# Altium schematic sheet Sheet1.SchDoc(Sheet1)
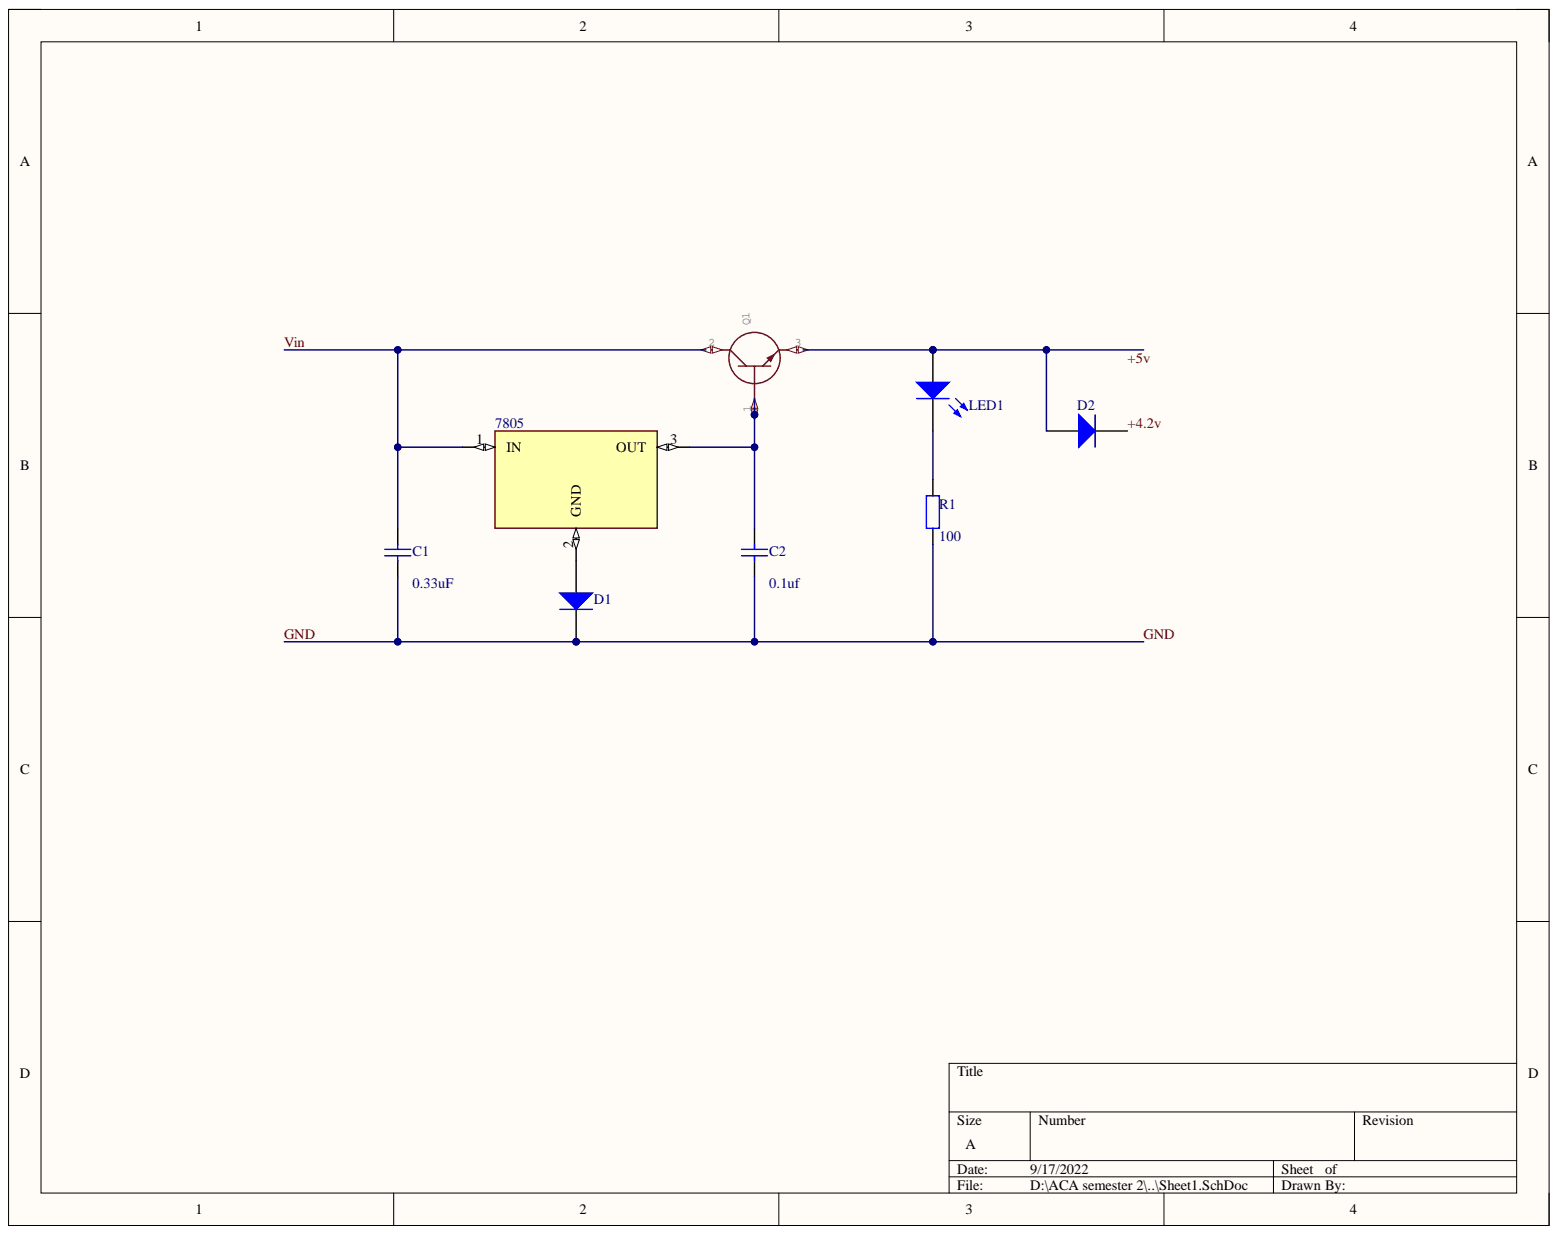
[diagram p0: Sheet1.SchDoc]

## Parts
7805  LM7805  [TO220]  (value not drawn)
C1  value 0.33uF  [RAD-0.3]  (hidden comment: Cap)
C2  value 0.1uf  [RAD-0.3]  (hidden comment: Cap)
D1  Diode 1N4007  [DO-41]  (value not drawn)
D2  Diode 1N4007  [DO-41]  (value not drawn)
Q1  (no value)  [TO304P498X1010X2089-3P]
R1  value 100  [AXIAL-0.4]  (hidden comment: Res2)
  LED1  [LED-1]

## Nets
Net_1: D2.1 Q1.3 .1
Net_2: R1.2 .2
+4.2v: D2.2
GND: C1.1 C2.1 D1.2 R1.1
Vin: 7805.1 C1.2 Q1.2
Net7805_2: 7805.2 D1.1
Net7805_3: 7805.3 C2.2 Q1.1
+5v:

## Library data of the parts
C1 C2 = Cap: Capacitor; Component Kind: Standard; LatestRevisionDate: 17-Jul-2002; LatestRevisionNote: Re-released for DXP Platform.; Library Name: Miscellaneous Devices.IntLib; PackageDescription: Radial Cap, Thru-Hole; 2 Leads; 0.3 in Pin Spacing; PackageReference: RAD-0.3; Published: 8-Jun-2000; Signal Integrity: Cap; Simulation: CAP; Publisher: Altium Limited
D1 D2 = Diode 1N4007: 1 Amp General Purpose Rectifier; Code_JEDEC: DO-204-AL; Component Kind: Standard; ComponentLink1Description: Manufacturer Link; ComponentLink1URL: http://www.fairchildsemi.com/; ComponentLink2Description: Datasheet; ComponentLink2URL: http://www.fairchildsemi.com/ds/1N%2F1N4001.pdf; DatasheetVersion: 1998; LatestRevisionDate: 17-Jul-2002; LatestRevisionNote: Re-released for DXP Platform.; Library Name: Miscellaneous Devices.IntLib; PackageDescription: Diode, Axial; Body 5.2 x 2.7 mm (LxDia. max), Lead Dia. 0.86 mm (max); PackageReference: DO-41; PackageVersion: Aug-1999; Published: 8-Jun-2000; Signal Integrity: 1N4007; Simulation: 1N4007; Publisher: Altium Limited
Q1 = 2SD313: Manufacturer_Name: MOSPEC; GateName_1: G$1; Component Kind: Standard; DeviceSetName: 2SD313; Height: 4.98mm; Library Name: 2SD313.Library.IntLib; LibraryName: 2SD313; Manufacturer_Part_Number: 2SD313; Package: TO304P498X1010X2089-3P; SymbolName_1: 2SD313
R1 = Res2: Resistor; Component Kind: Standard; LatestRevisionDate: 17-Jul-2002; LatestRevisionNote: Re-released for DXP Platform.; Library Name: Miscellaneous Devices.IntLib; PackageDescription: Axial Device, Thru-Hole; 2 Leads; 0.4 in Pin Spacing; PackageReference: AXIAL-0.4; Published: 8-Jun-2000; Signal Integrity: Res; Simulation: RESISTOR; Publisher: Altium Limited
 = LED1: Typical RED GaAs LED; Component Kind: Standard; LatestRevisionDate: 08-Jul-2005; LatestRevisionNote: Mech Layer 1 removed.; Library Name: Miscellaneous Devices.IntLib; PackageDescription: LED; 2 Leads; PackageReference: LED-1; Published: 8-Jun-2000; Simulation: LED1; Publisher: Altium Limited
7805 = LM7805: Availability: Unavailable; Component Kind: Standard; Library Name: LM7805.IntLib; MP: LM7805; Package: None; Price: None
